# Altium schematic sheet 08_WIRELESS.SchDoc
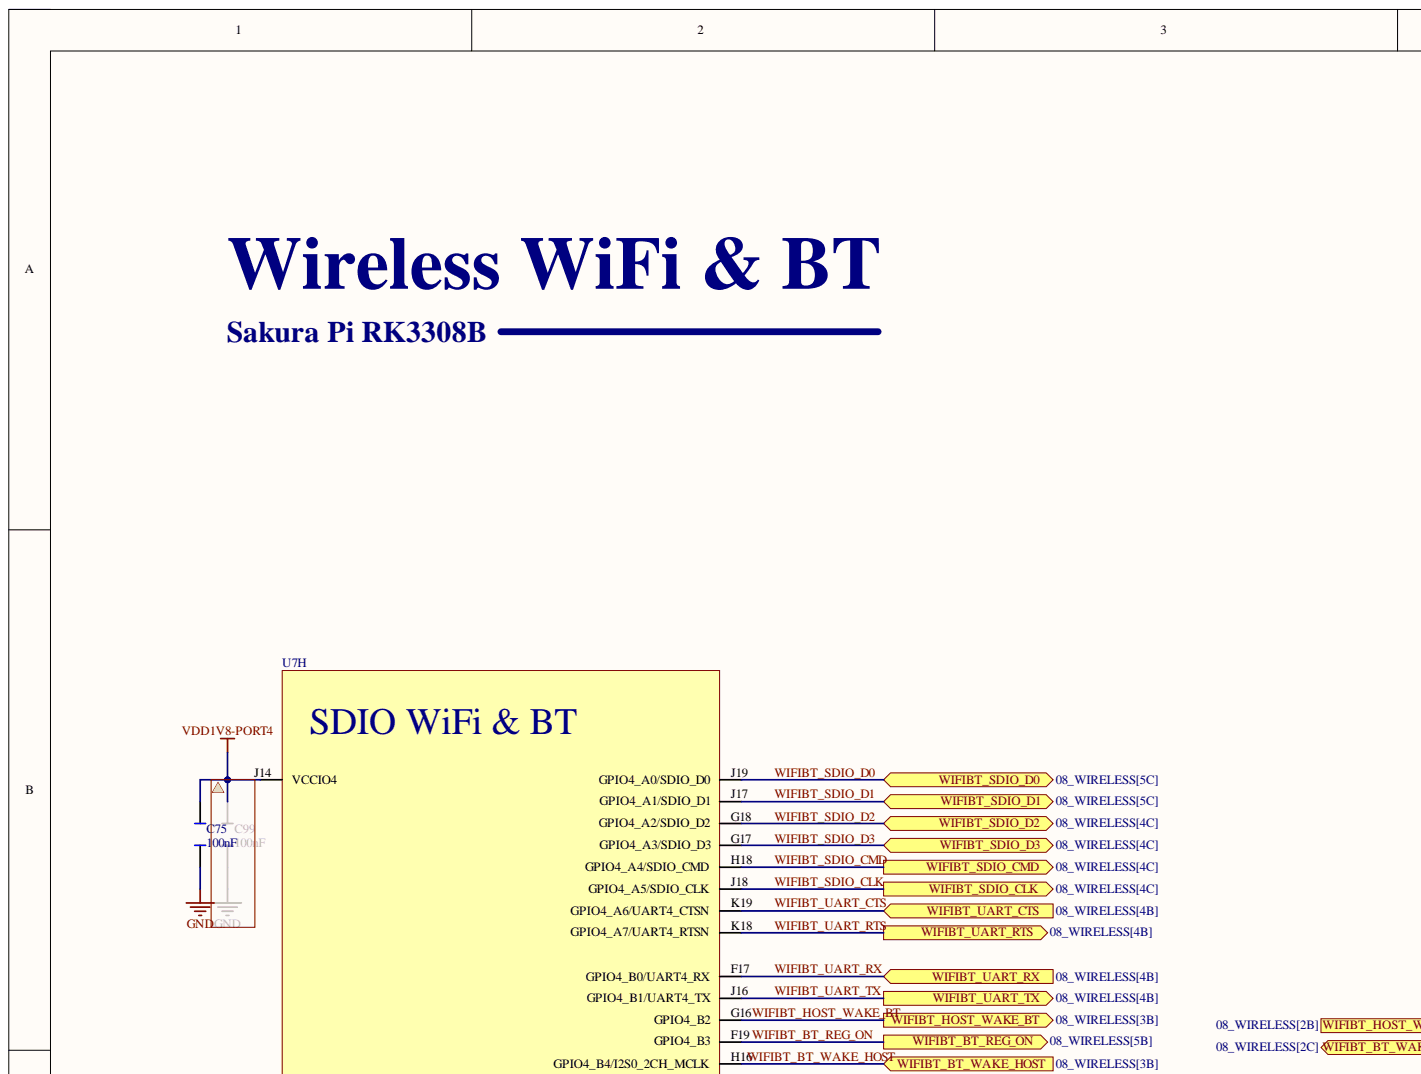
[diagram p0: 08_WIRELESS.SchDoc, top left]
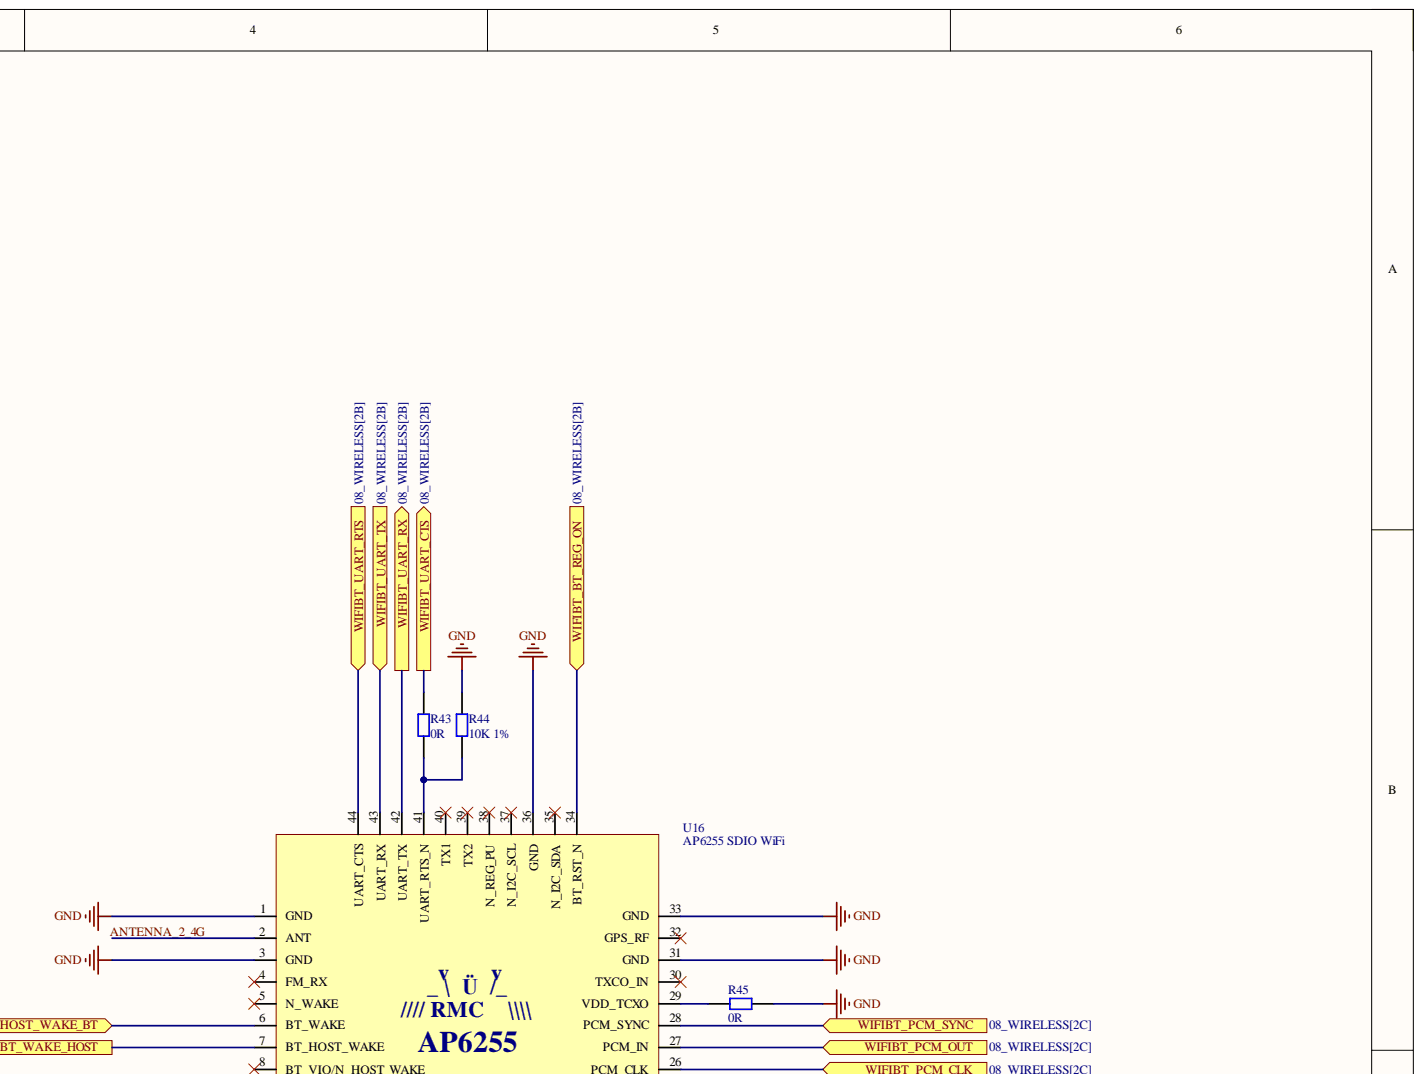
[diagram p1: 08_WIRELESS.SchDoc, top right]
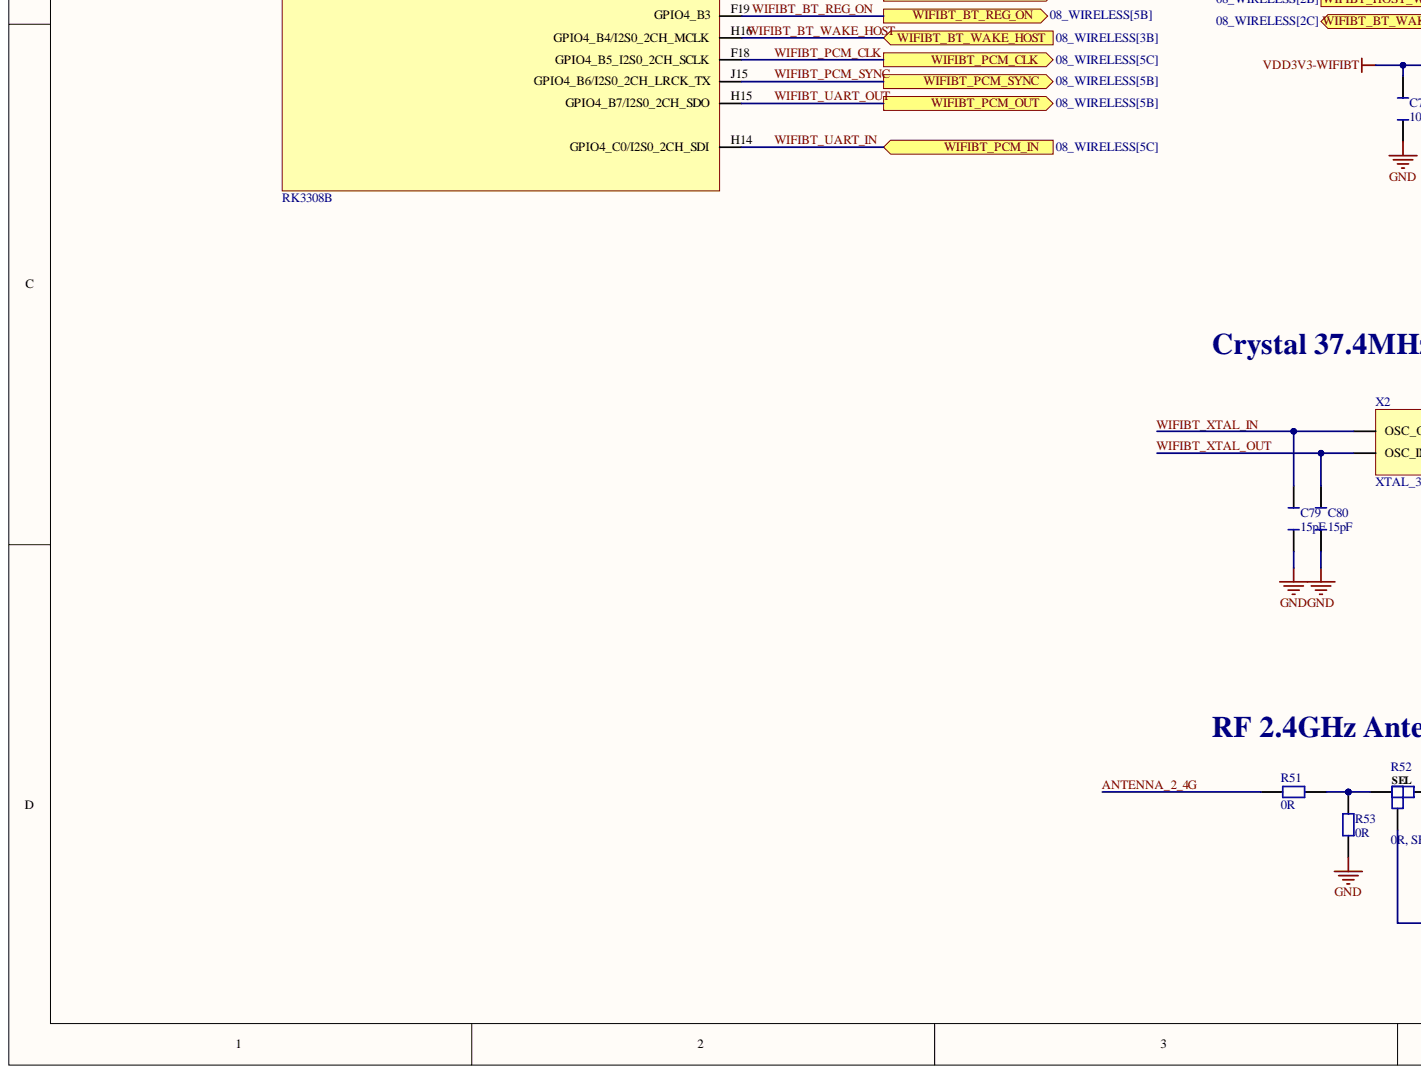
[diagram p2: 08_WIRELESS.SchDoc, bottom left]
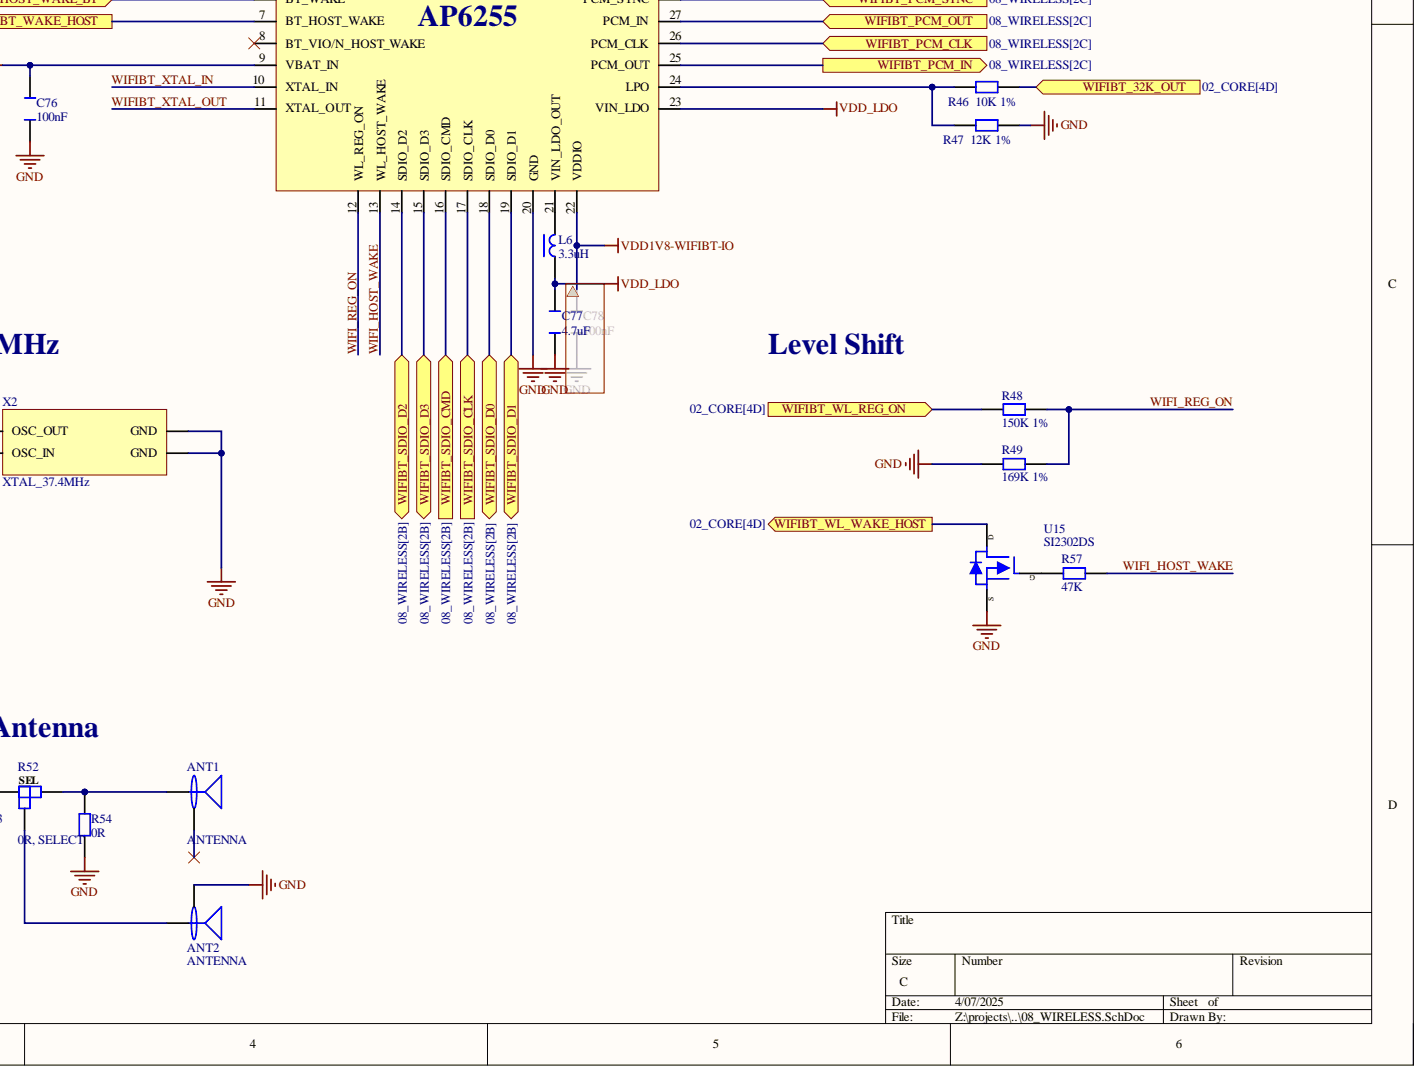
[diagram p3: 08_WIRELESS.SchDoc, bottom right]

## Parts
ANT1  ANTENNA  [CROSSAIR_W01]
ANT2  ANTENNA  [U.FL-R-SMT]
C75  100nF  [C0402]
C76  100nF  [C0402]
C77  4.7uF  [C0402]
C79  15pF  [C0402]
C80  15pF  [C0402]
L6  3.3uH  [L0806]
R43  0R  [R0402]
R44  10K 1%  [R0402]
R45  0R  [R0402]
R46  10K 1%  [R0402]
R47  12K 1%  [R0402]
R48  150K 1%  [R0402]
R49  169K 1%  [R0402]
R51  0R  [R0402]
R52  0R, SELECT  [R0402-SEL90]
R53  0R  [R0402]
R54  0R  [R0402]
R57  47K  [R0402]
U7  RK3308B  [RK3308B]  (part H)
U15  SI2302DS  [SI2302DS]
U16  AP6255 SDIO WiFi  [AP6255]
X2  XTAL_37.4MHz  [SMD1612]

## Ports
WIFIBT_32K_OUT, WIFIBT_BT_REG_ON, WIFIBT_BT_WAKE_HOST, WIFIBT_HOST_WAKE_BT, WIFIBT_PCM_CLK, WIFIBT_PCM_IN, WIFIBT_PCM_OUT, WIFIBT_PCM_SYNC, WIFIBT_SDIO_CLK, WIFIBT_SDIO_CMD, WIFIBT_SDIO_D0, WIFIBT_SDIO_D1, WIFIBT_SDIO_D2, WIFIBT_SDIO_D3, WIFIBT_UART_CTS, WIFIBT_UART_RTS, WIFIBT_UART_RX, WIFIBT_UART_TX, WIFIBT_WL_REG_ON, WIFIBT_WL_WAKE_HOST

## Nets
GND: ANT2.2 C75.1 C76.1 C77.1 C79.1 C80.1 R44.2 R45.2 R47.2 R49.1 R53.1 R54.1 U15.2 U16.1(GND) U16.3(GND) U16.20(GND) U16.31(GND) U16.33(GND) U16.36(GND) X2.2(GND) X2.4(GND)
VDD1V8-PORT4: C75.2 U7.J14(VCCIO4)
VDD1V8-WIFIBT-IO: U16.22(VDDIO)
VDD3V3-WIFIBT: C76.2 U16.9(VBAT_IN)
VDD_LDO: C77.2 L6.1 U16.23(VIN_LDO)
ANTENNA_2_4G: R51.1 U16.2(ANT)
WIFI_HOST_WAKE: R57.2 U16.13(WL_HOST_WAKE)
WIFI_REG_ON: R48.2 R49.2 U16.12(WL_REG_ON)
WIFIBT_32K_OUT: R46.1 ; port WIFIBT_32K_OUT
WIFIBT_BT_REG_ON: U7.F19(GPIO4_B3) U16.34(BT_RST_N) ; port WIFIBT_BT_REG_ON
WIFIBT_BT_WAKE_HOST: U7.H16(GPIO4_B4/I2S0_2CH_MCLK) U16.7(BT_HOST_WAKE) ; port WIFIBT_BT_WAKE_HOST
WIFIBT_HOST_WAKE_BT: U7.G16(GPIO4_B2) U16.6(BT_WAKE) ; port WIFIBT_HOST_WAKE_BT
WIFIBT_PCM_CLK: U7.F18(GPIO4_B5_I2S0_2CH_SCLK) U16.26(PCM_CLK) ; port WIFIBT_PCM_CLK
WIFIBT_PCM_SYNC: U7.J15(GPIO4_B6/I2S0_2CH_LRCK_TX) U16.28(PCM_SYNC) ; port WIFIBT_PCM_SYNC
WIFIBT_SDIO_CLK: U7.J18(GPIO4_A5/SDIO_CLK) U16.17(SDIO_CLK) ; port WIFIBT_SDIO_CLK
WIFIBT_SDIO_CMD: U7.H18(GPIO4_A4/SDIO_CMD) U16.16(SDIO_CMD) ; port WIFIBT_SDIO_CMD
WIFIBT_SDIO_D0: U7.J19(GPIO4_A0/SDIO_D0) U16.18(SDIO_D0) ; port WIFIBT_SDIO_D0
WIFIBT_SDIO_D1: U7.J17(GPIO4_A1/SDIO_D1) U16.19(SDIO_D1) ; port WIFIBT_SDIO_D1
WIFIBT_SDIO_D2: U7.G18(GPIO4_A2/SDIO_D2) U16.14(SDIO_D2) ; port WIFIBT_SDIO_D2
WIFIBT_SDIO_D3: U7.G17(GPIO4_A3/SDIO_D3) U16.15(SDIO_D3) ; port WIFIBT_SDIO_D3
WIFIBT_UART_CTS: R43.2 U7.K19(GPIO4_A6/UART4_CTSN) ; port WIFIBT_UART_CTS
WIFIBT_UART_IN: U7.H14(GPIO4_C0/I2S0_2CH_SDI) U16.25(PCM_OUT) ; port WIFIBT_PCM_IN
WIFIBT_UART_OUT: U7.H15(GPIO4_B7/I2S0_2CH_SDO) U16.27(PCM_IN) ; port WIFIBT_PCM_OUT
WIFIBT_UART_RTS: U7.K18(GPIO4_A7/UART4_RTSN) U16.44(UART_CTS) ; port WIFIBT_UART_RTS
WIFIBT_UART_RX: U7.F17(GPIO4_B0/UART4_RX) U16.42(UART_TX) ; port WIFIBT_UART_RX
WIFIBT_UART_TX: U7.J16(GPIO4_B1/UART4_TX) U16.43(UART_RX) ; port WIFIBT_UART_TX
WIFIBT_WL_REG_ON: R48.1 ; port WIFIBT_WL_REG_ON
WIFIBT_WL_WAKE_HOST: U15.3 ; port WIFIBT_WL_WAKE_HOST
WIFIBT_XTAL_IN: C79.2 U16.10(XTAL_IN) X2.3(OSC_OUT)
WIFIBT_XTAL_OUT: C80.2 U16.11(XTAL_OUT) X2.1(OSC_IN)
NetANT1_1: ANT1.1 R52.2 R54.2
NetANT2_1: ANT2.1 R52.3
NetL6_2: L6.2 U16.21(VIN_LDO_OUT)
NetR43_1: R43.1 R44.1 U16.41(UART_RTS_N)
NetR45_1: R45.1 U16.29(VDD_TCXO)
NetR46_2: R46.2 R47.1 U16.24(LPO)
NetR51_2: R51.2 R52.1 R53.2
NetR57_1: R57.1 U15.1

Not connected: ANT1 2; U16 4(FM_RX) 5(N_WAKE) 8(BT_VIO/N_HOST_WAKE) 30(TXCO_IN) 32(GPS_RF) 35(N_I2C_SDA) 37(N_I2C_SCL) 38(N_REG_PU) 39(TX2) 40(TX1)

## Library data of the parts
C75 C76 = C_100NF: Component Kind: Standard; Library Name: rk3308b.SchLib
C77 = C_4.7UF: Component Kind: Standard; Library Name: rk3308b.SchLib
C79 C80 = C_15PF: Component Kind: Standard; Library Name: rk3308b.SchLib
L6 = L_3.3UH: Component Kind: Standard; Library Name: rk3308b.SchLib
R43 R45 R51 R53 R54 = R_0R: Component Kind: Standard; Library Name: rk3308b.SchLib
R44 R46 = R_10K_P1: Component Kind: Standard; Library Name: rk3308b.SchLib
R47 = R_12K_P1: Component Kind: Standard; Library Name: rk3308b.SchLib
R48 = R_150K_P1: Component Kind: Standard; Library Name: rk3308b.SchLib
R49 = R_169K_P1: Component Kind: Standard; Library Name: rk3308b.SchLib
R52 = R_SELECT: Component Kind: Standard; Library Name: rk3308b.SchLib
R57 = R_47K: Component Kind: Standard; Library Name: rk3308b.SchLib
U16 = AP6255: Component Kind: Standard; Library Name: rk3308b.SchLib
ANT1 ANT2 = ANTENNA: Component Kind: Standard; Library Name: rk3308b.SchLib
U7 = RK3308B: Component Kind: Standard; Library Name: rk3308b.SchLib
U15 = SI2302DS: Component Kind: Standard; Library Name: rk3308b.SchLib
X2 = XTAL_37.4MHz: Component Kind: Standard; Library Name: rk3308b.SchLib
